# Altium schematic sheet CODEC.SchDoc
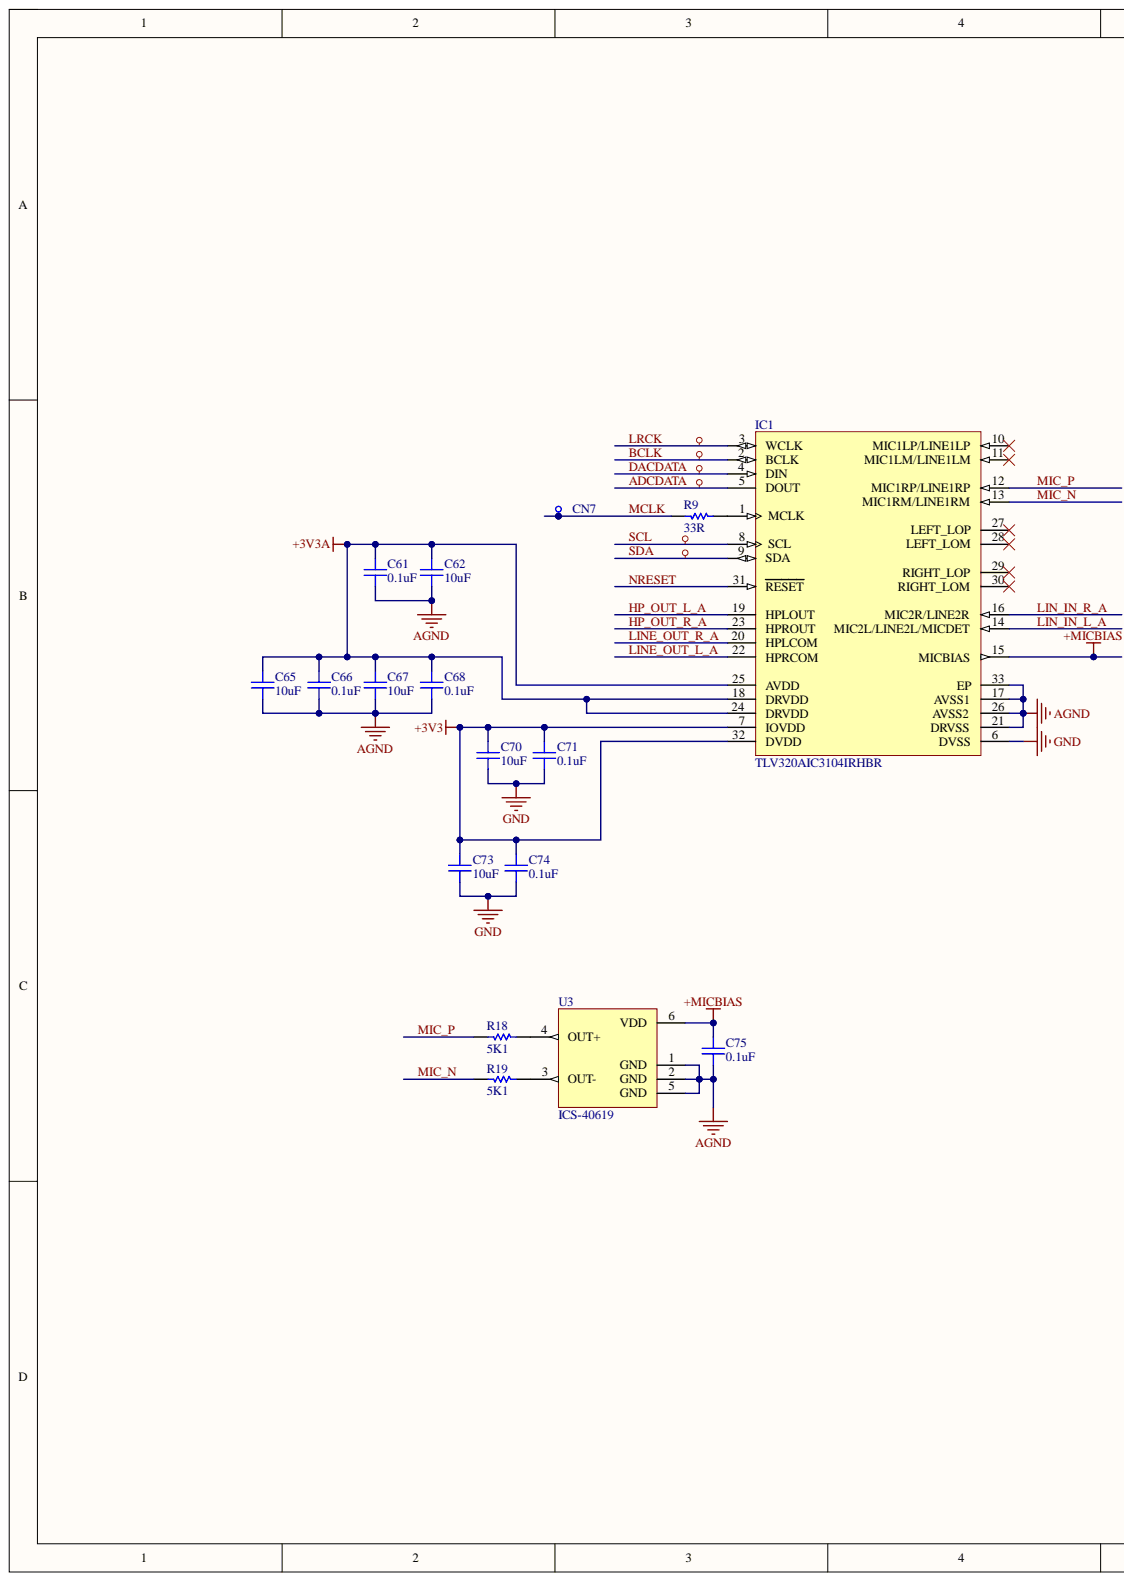
[diagram p0: CODEC.SchDoc, left]
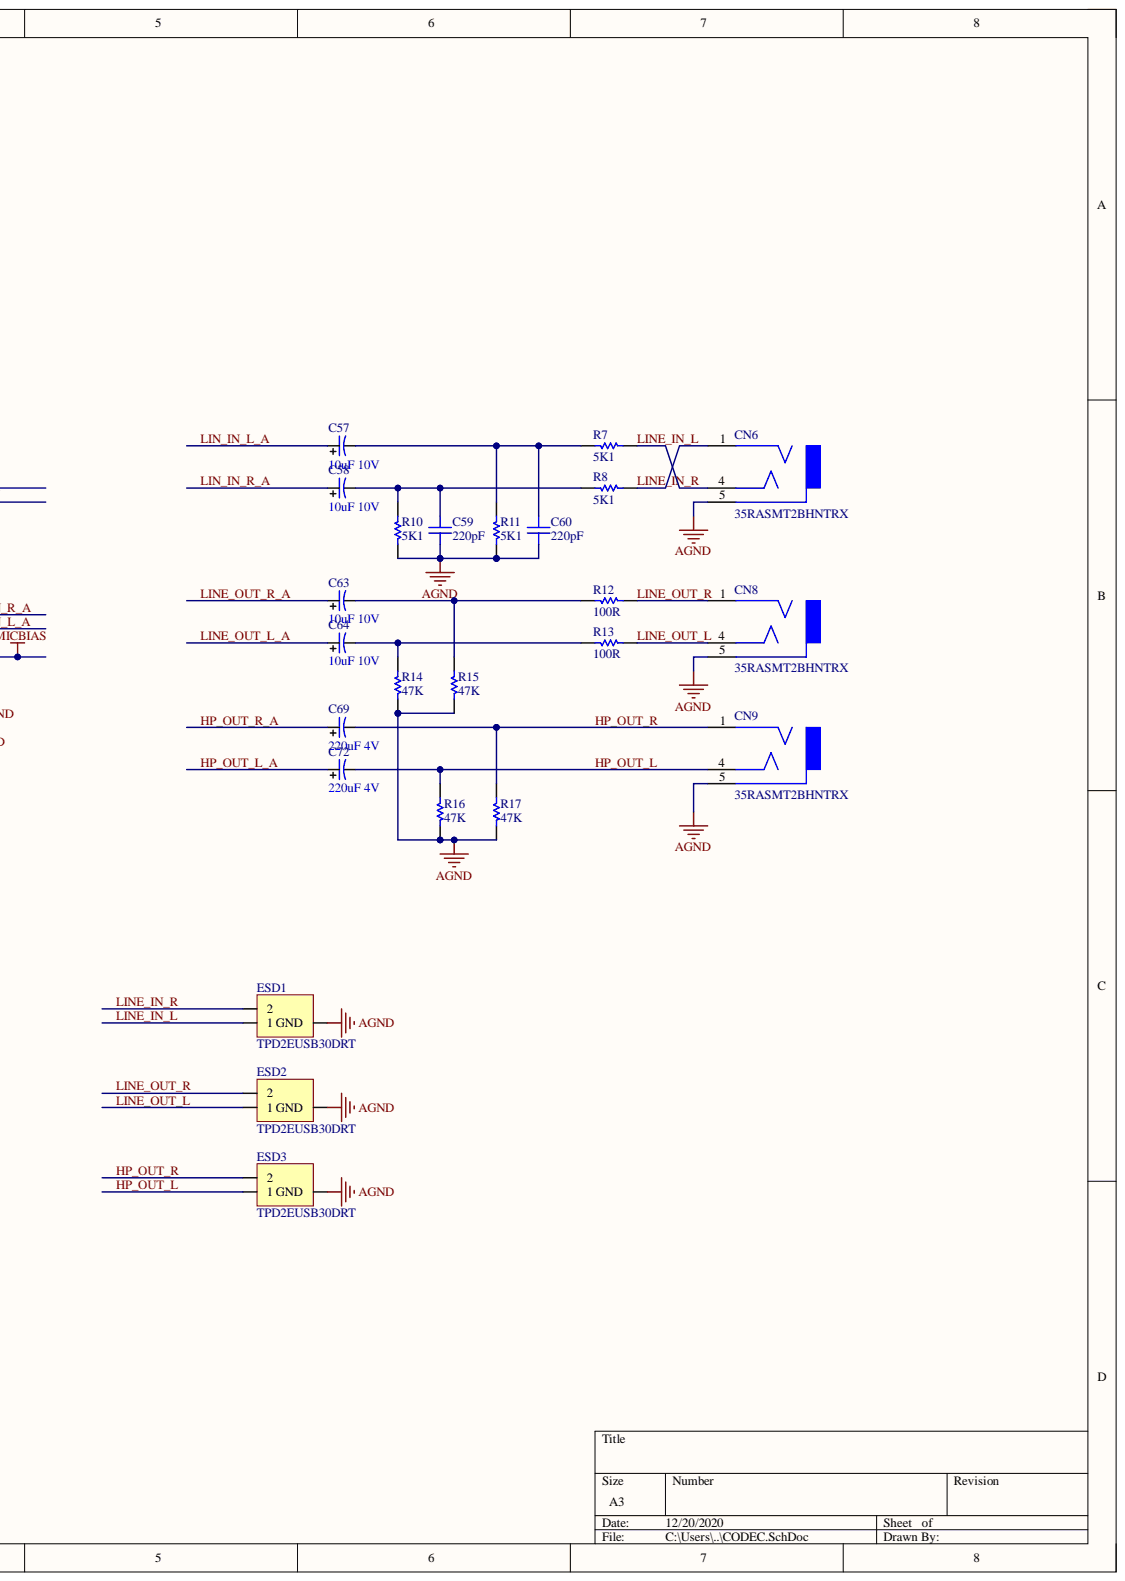
[diagram p1: CODEC.SchDoc, right]

## Parts
C57  10uF 10V  [CAP_4X5.8]
C58  10uF 10V  [CAP_4X5.8]
C59  220pF  [AA-0402]
C60  220pF  [AA-0402]
C61  0.1uF  [AA-0402]
C62  10uF  [AA-0402]
C63  10uF 10V  [CAP_4X5.8]
C64  10uF 10V  [CAP_4X5.8]
C65  10uF  [AA-0402]
C66  0.1uF  [AA-0402]
C67  10uF  [AA-0402]
C68  0.1uF  [AA-0402]
C69  220uF 4V  [CAP_5X5.8_E61]
C70  10uF  [AA-0402]
C71  0.1uF  [AA-0402]
C72  220uF 4V  [CAP_5X5.8_E61]
C73  10uF  [AA-0402]
C74  0.1uF  [AA-0402]
C75  0.1uF  [AA-0402]
CN6  35RASMT2BHNTRX  [35RASMT2BHNTRX]
CN7  TEST POINT  [TESTPAD-1MM]  (value not drawn)  (no BOM)
CN8  35RASMT2BHNTRX  [35RASMT2BHNTRX]
CN9  35RASMT2BHNTRX  [35RASMT2BHNTRX]
ESD1  TPD2EUSB30DRT  [SOT-3]
ESD2  TPD2EUSB30DRT  [SOT-3]
ESD3  TPD2EUSB30DRT  [SOT-3]
IC1  TLV320AIC3104IRHBR  [RHB32-3450X3450TP]
R7  5K1  [AA-0402]
R8  5K1  [AA-0402]
R9  33R  [AA-0402]
R10  5K1  [AA-0402]
R11  5K1  [AA-0402]
R12  100R  [AA-0603]
R13  100R  [AA-0603]
R14  47K  [AA-0402]
R15  47K  [AA-0402]
R16  47K  [AA-0402]
R17  47K  [AA-0402]
R18  5K1  [AA-0402]
R19  5K1  [AA-0402]
U3  ICS‐40619  [ICS-40619]

## Nets
+3V3: C70.1 C71.1 C73.1 C74.1 IC1.7(IOVDD) IC1.32(DVDD)
+3V3A: C61.2 C62.2 C65.2 C66.2 C67.2 C68.2 IC1.18(DRVDD) IC1.24(DRVDD) IC1.25(AVDD)
+MICBIAS: C75.2 IC1.15(MICBIAS) U3.6(VDD)
AGND: C59.2 C60.2 C61.1 C62.1 C65.1 C66.1 C67.1 C68.1 C75.1 CN6.5 CN8.5 CN9.5 ESD1.3 ESD2.3 ESD3.3 IC1.17(AVSS1) IC1.21(DRVSS) IC1.26(AVSS2) IC1.33(EP) R10.1 R11.1 R14.2 R15.2 R16.2 R17.2 U3.1(GND) U3.2(GND) U3.5(GND)
GND: C70.2 C71.2 C73.2 C74.2 IC1.6(DVSS)
ADCDATA: IC1.5(DOUT)
BCLK: IC1.2(BCLK)
DACDATA: IC1.4(DIN)
HP_OUT_L: C72.2 CN9.4 ESD3.1 R16.1
HP_OUT_L_A: C72.1 IC1.19(HPLOUT)
HP_OUT_R: C69.2 CN9.1 ESD3.2 R17.1
HP_OUT_R_A: C69.1 IC1.23(HPROUT)
LIN_IN_L_A: C57.1 IC1.14(MIC2L/LINE2L/MICDET)
LIN_IN_R_A: C58.1 IC1.16(MIC2R/LINE2R)
LINE_IN_L: CN6.4 ESD1.1 R7.2
LINE_IN_R: CN6.1 ESD1.2 R8.2
LINE_OUT_L: CN8.4 ESD2.1 R13.2
LINE_OUT_L_A: C64.1 IC1.22(HPRCOM)
LINE_OUT_R: CN8.1 ESD2.2 R12.2
LINE_OUT_R_A: C63.1 IC1.20(HPLCOM)
LRCK: IC1.3(WCLK)
MCLK: CN7.TST R9.1
MIC_N: IC1.13(MIC1RM/LINE1RM) R19.1
MIC_P: IC1.12(MIC1RP/LINE1RP) R18.1
NRESET: IC1.31(RESET)
SCL: IC1.8(SCL)
SDA: IC1.9(SDA)
NetC57_2: C57.2 C60.1 R7.1 R11.2
NetC58_2: C58.2 C59.1 R8.1 R10.2
NetC63_2: C63.2 R12.1 R15.1
NetC64_2: C64.2 R13.1 R14.1
NetIC1_1: IC1.1(MCLK) R9.2
NetR18_2: R18.2 U3.4(OUT+)
NetR19_2: R19.2 U3.3(OUT-)

Not connected: IC1 10(MIC1LP/LINE1LP) 11(MIC1LM/LINE1LM) 27(LEFT_LOP) 28(LEFT_LOM) 29(RIGHT_LOP) 30(RIGHT_LOM)

## Library data of the parts
C57 C58 C63 C64 C69 C72 = 02020096D: 100uFx63V SMD low ESR Al ELECT CAP 10.0x10.0 Ir=400mA Z=0,35 -55+105; Manufacturer: Cornell Dubilier - CDE; Manufacturer Part Number: AFK107M63G24T-F; Allegro PCB Footprint: CAE10X105; COMPHEIGHT: 10.5; Database Table Name: Capacitor; Gaminys: 8DEV; Komentaras: $; Tolerance: +-20%; Voltage: 63V; ActivepartsID: https://eu.mouser.com/datasheet/2/88/AFK-V-875804.pdf; Availability: in stock; Component Kind: Standard; Data: 1/7/2020; Distributor: Mouser; Distributor Part Number: 5985-AFK63V100-F; Library Name: ALT_DB.DBLIB; Package: T&R500; Part Number: 02020096D; Part Type: Electrolytic\Al SMD low ESR; Price: 0.504; SMD: Yes; Value: 100uFx63V; Datasheet: E:\pdf\KONDENSATORIAI\LOW_ESR\AFK-V-875804.pdf
C59 C60 = 00005500W: Database Table Name: Capacitor; Value: 0.1uF; Component Kind: Standard; Library Name: ALT_DB.DBLIB
C61 C62 C65-C68 C70 C71 C73-C75 = 00005500W: 100nF 16V 10% X7R 0402  -55+125; Database Table Name: Capacitor; Component Kind: Standard; Library Name: ALT_DB.DBLIB
  C61 C66 C68 C71 C74 C75: Value: 0.1uF
CN7 = TESTPOINT: Library Name: ULTIMA_LIB.SCHLIB
IC1 = TLV320AIC3104IRHBR: Low-Power Stereo CODEC with 6 Inputs, 6 Outputs, HP Amp and Enhanced Digital Effects, 2 ADCs and 2 DACs, 102 dB DAC SNR, -40 to 85 degC, 32-pin QFN (RHB), Green (RoHS & no Sb/Br); Manufacturer: Texas Instruments; # ADCs (typ): 2; Additional Features: LP Bypass, Notch Filtering, 3D, EQ, Matrix Mixing, PLL; Battery Powered: TRUE; Control Interface: I2C; DAC SNR: 102; Digital Audio Interfaces: L, R, I2S, DSP, TDM; Sampling Rate (Max) (kHz): 96; # DACs: 2; Code_JEDEC: MO-220; Component Kind: Standard; ComponentLink1Description: Datasheet; ComponentLink1URL: http://www.ti.com/general/docs/lit/getliterature.tsp?genericPartNumber=TLV320AIC3104&fileType=pdf; DatasheetVersion: SLAS510C; Mounting Technology: Surface Mount; PackageDescription: 32-Pin Plastic Quad Flat No-Lead, 5 x 5 mm Body, 0.5 mm Pitch, 3.45 x 3.45 mm Exposed Pad; PackageReference: RHB32-3450X3450TP; PackageVersion: revD, Jun-2011; PartNumber: TLV320AIC3104IRHBR; Supplier 1: Digi-Key; Supplier 2: Digi-Key; Supplier 3: Digi-Key; Supplier 4: Newark; Supplier 5: Mouser; Supplier Part Number 1: 296-26818-2-ND; Supplier Part Number 2: 296-26818-1-ND; Supplier Part Number 3: 296-26818-6-ND; Supplier Part Number 4: 52M6077; Supplier Part Number 5: 595-TLV320C3104IRHBR; Library Name: ULTIMA_LIB.SCHLIB
R7-R19 = 10012142: Database Table Name: Resistor; Component Kind: Standard; Library Name: ALT_DB.DBLIB
  R7 R8 R10 R11 R18 R19: Value: 5K1
  R14-R17: Value: 47K
CN6 CN8 CN9 = 35RASMT2BHNTRX: Component Kind: Standard; Library Name: ULTIMA_LIB.SCHLIB
ESD1-ESD3 = TPD2EUSB30DRT: Component Kind: Standard; Library Name: ULTIMA_LIB.SCHLIB
U3 = ICS‐40619: Component Kind: Standard; Library Name: ULTIMA_LIB.SCHLIB
